# Altium schematic sheet LEDs and LP3943.SchDoc
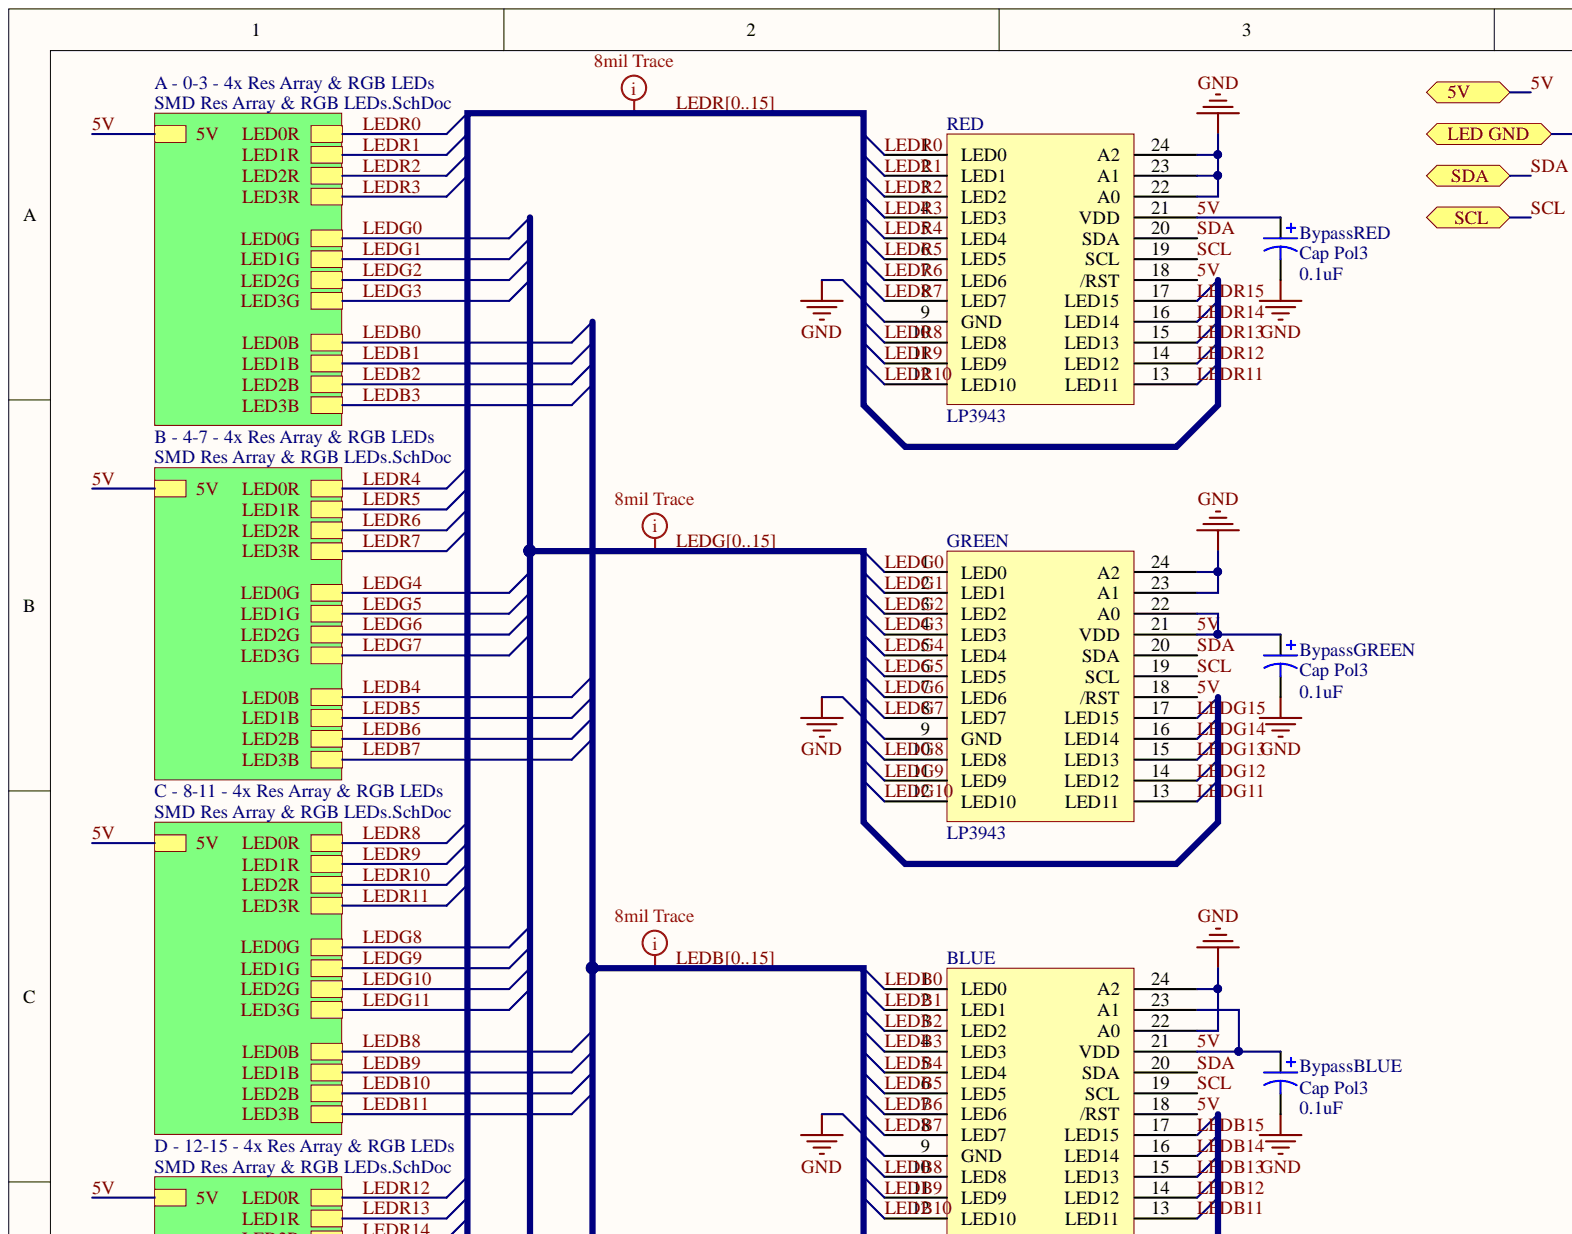
[diagram p0: LEDs and LP3943.SchDoc]

## Parts
BLUE  LP3943  [LP3943]  (value not drawn)
BypassBLUE  Cap Pol3  value 0.1uF  [C0805]
BypassGREEN  Cap Pol3  value 0.1uF  [C0805]
BypassRED  Cap Pol3  value 0.1uF  [C0805]
GREEN  LP3943  [LP3943]
RED  LP3943  [LP3943]

## Ports
5V, LED GND, SCL, SDA

## Nets
5V: BLUE.18(/RST) BLUE.21(VDD) BLUE.23(A1) BypassBLUE.1 BypassGREEN.1 BypassRED.1 GREEN.18(/RST) GREEN.21(VDD) GREEN.22(A0) RED.18(/RST) RED.21(VDD) ; port 5V
GND: BLUE.9(GND) BLUE.22(A0) BLUE.24(A2) BypassBLUE.2 BypassGREEN.2 BypassRED.2 GREEN.9(GND) GREEN.23(A1) GREEN.24(A2) RED.9(GND) RED.22(A0) RED.23(A1) RED.24(A2) ; port LED GND
LEDB0: BLUE.1(LED0)  [also labelled LEDB]
LEDB1: BLUE.2(LED1)  [also labelled LEDB]
LEDB2: BLUE.3(LED2)  [also labelled LEDB]
LEDB3: BLUE.4(LED3)  [also labelled LEDB]
LEDB4: BLUE.5(LED4)  [also labelled LEDB]
LEDB5: BLUE.6(LED5)  [also labelled LEDB]
LEDB6: BLUE.7(LED6)  [also labelled LEDB]
LEDB7: BLUE.8(LED7)  [also labelled LEDB]
LEDB8: BLUE.10(LED8)  [also labelled LEDB]
LEDB9: BLUE.11(LED9)  [also labelled LEDB]
LEDB10: BLUE.12(LED10)  [also labelled LEDB]
LEDB11: BLUE.13(LED11)  [also labelled LEDB]
LEDB12: BLUE.14(LED12)  [also labelled LEDB]
LEDB13: BLUE.15(LED13)  [also labelled LEDB]
LEDB14: BLUE.16(LED14)  [also labelled LEDB]
LEDB15: BLUE.17(LED15)  [also labelled LEDB]
LEDG0: GREEN.1(LED0)  [also labelled LEDG]
LEDG1: GREEN.2(LED1)  [also labelled LEDG]
LEDG2: GREEN.3(LED2)  [also labelled LEDG]
LEDG3: GREEN.4(LED3)  [also labelled LEDG]
LEDG4: GREEN.5(LED4)  [also labelled LEDG]
LEDG5: GREEN.6(LED5)  [also labelled LEDG]
LEDG6: GREEN.7(LED6)  [also labelled LEDG]
LEDG7: GREEN.8(LED7)  [also labelled LEDG]
LEDG8: GREEN.10(LED8)  [also labelled LEDG]
LEDG9: GREEN.11(LED9)  [also labelled LEDG]
LEDG10: GREEN.12(LED10)  [also labelled LEDG]
LEDG11: GREEN.13(LED11)  [also labelled LEDG]
LEDG12: GREEN.14(LED12)  [also labelled LEDG]
LEDG13: GREEN.15(LED13)  [also labelled LEDG]
LEDG14: GREEN.16(LED14)  [also labelled LEDG]
LEDG15: GREEN.17(LED15)  [also labelled LEDG]
LEDR0: RED.1(LED0)  [also labelled LEDR]
LEDR1: RED.2(LED1)  [also labelled LEDR]
LEDR2: RED.3(LED2)  [also labelled LEDR]
LEDR3: RED.4(LED3)  [also labelled LEDR]
LEDR4: RED.5(LED4)  [also labelled LEDR]
LEDR5: RED.6(LED5)  [also labelled LEDR]
LEDR6: RED.7(LED6)  [also labelled LEDR]
LEDR7: RED.8(LED7)  [also labelled LEDR]
LEDR8: RED.10(LED8)  [also labelled LEDR]
LEDR9: RED.11(LED9)  [also labelled LEDR]
LEDR10: RED.12(LED10)  [also labelled LEDR]
LEDR11: RED.13(LED11)  [also labelled LEDR]
LEDR12: RED.14(LED12)  [also labelled LEDR]
LEDR13: RED.15(LED13)  [also labelled LEDR]
LEDR14: RED.16(LED14)  [also labelled LEDR]
LEDR15: RED.17(LED15)  [also labelled LEDR]
SCL: BLUE.19(SCL) GREEN.19(SCL) RED.19(SCL) ; port SCL
SDA: BLUE.20(SDA) GREEN.20(SDA) RED.20(SDA) ; port SDA

## Library data of the parts
BypassBLUE BypassGREEN BypassRED = Cap Pol3: Polarized Capacitor (Surface Mount); Component Kind: Standard; LatestRevisionDate: 29-May-2009; LatestRevisionNote: IPC-7351 Footprint Added.; Library Name: Miscellaneous Devices.IntLib; PackageDescription: Chip Capacitor; PackageReference: C0805; Published: 8-Jun-2000; Signal Integrity: Cap Pol; Simulation: CAP; Publisher: Altium Limited
BLUE GREEN RED = LP3943: Component Kind: Standard; Library Name: Microprocessors2.SchLib
